# Altium schematic sheet Sheet4.SchDoc
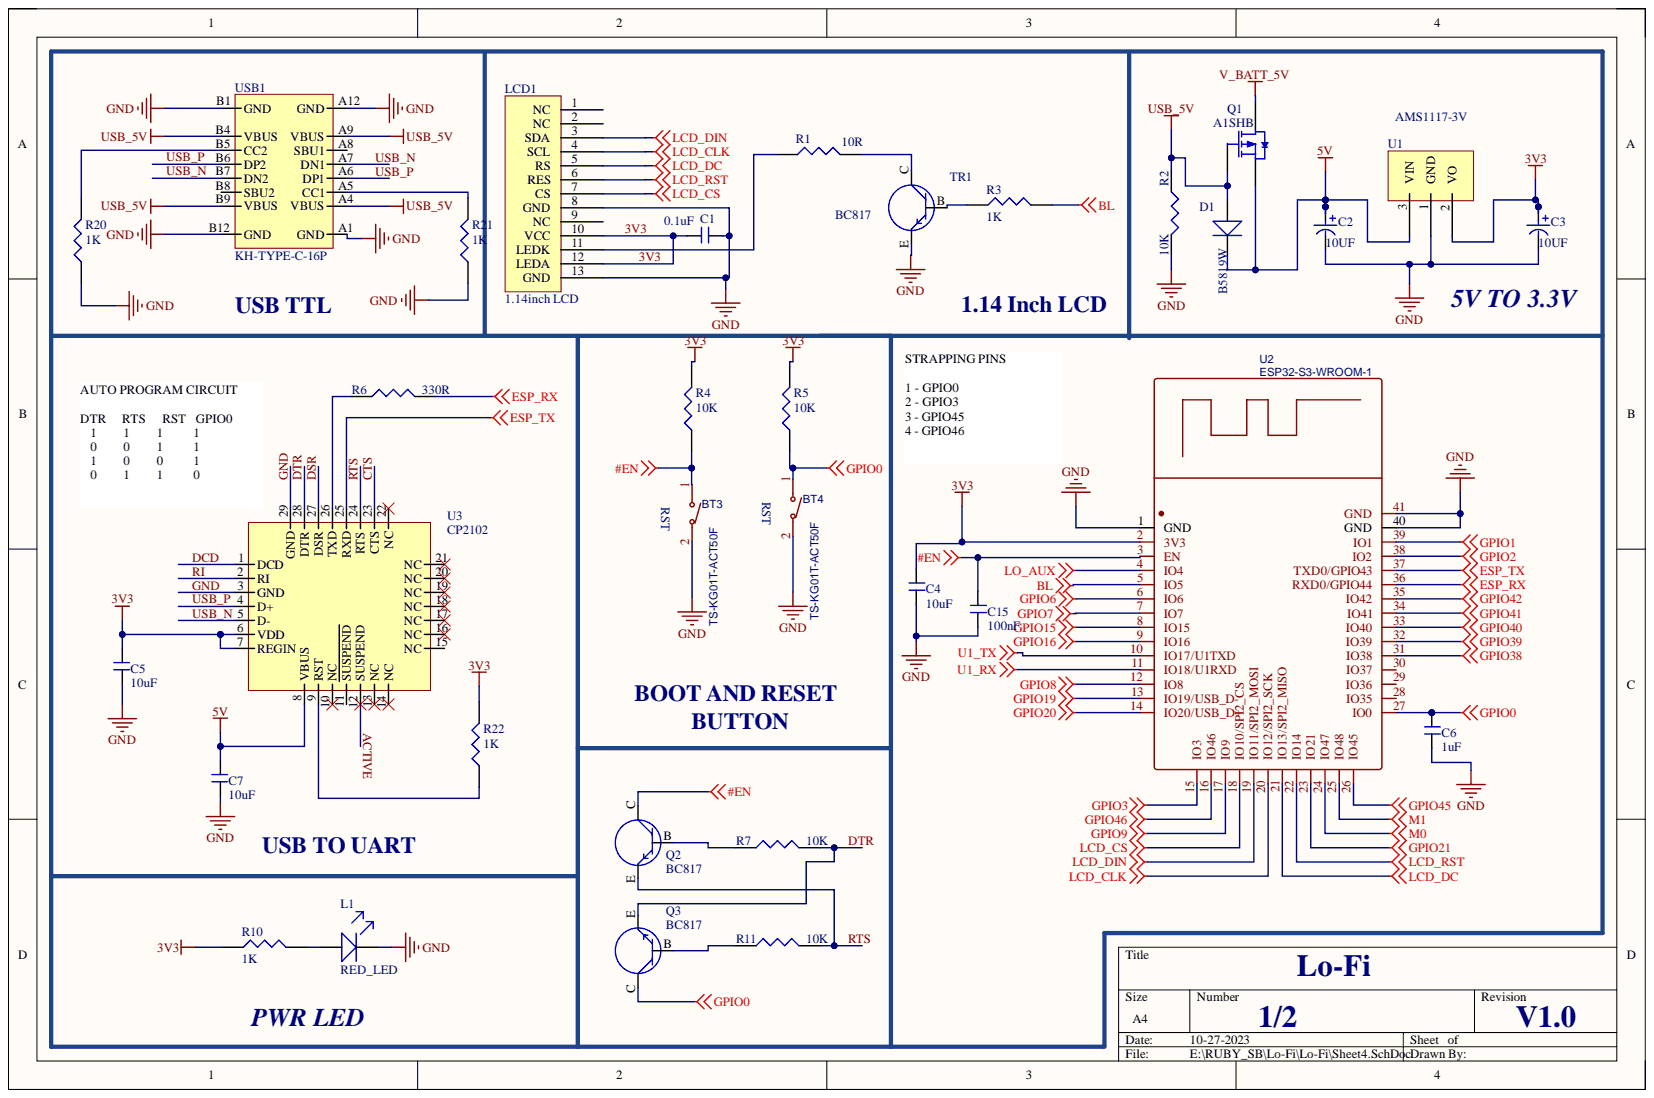
[diagram p0: Sheet4.SchDoc]

## Parts
BT3  TS-KG01T-ACT50F  [SW_SMD_L3.9_W2.9 P4.15]
BT4  TS-KG01T-ACT50F  [SW_SMD_L3.9_W2.9 P4.15]
BT?  TS-KG01T-ACT50F  [SW_SMD_L3.9_W2.9 P4.15]
C1  0.1uF  [C_0603]
C2  10UF  [Tantlum_A_3216]
C3  10UF  [Tantlum_A_3216]
C4  10uF  [C_0603]
C5  10uF  [C_0603]
C6  1uF  [C_0603]
C7  10uF  [C_0603]
C15  100nF  [C_0603]
D1  B5819W  [SOD-123]
L1  RED_LED  [LED_0603]
LCD1  1.14inch LCD  [1.14 inch]
Q1  A1SHB  [BSS84]
Q2  BC817  [SOT23]
Q3  BC817  [SOT23]
R1  10R  [R_0603]
R2  10K  [R_0603]
R3  1K  [R_0603]
R4  10K  [R_0603]
R5  10K  [R_0603]
R6  330R  [R_0603]
R7  10K  [R_0603]
R10  1K  [R_0603]
R11  10K  [R_0603]
R20  1K  [R_0603]
R21  1K  [R_0603]
R22  1K  [R_0603]
R?  10K  [R_0603]  (value not drawn)
TR1  BC817  [SOT23]
U1  AMS1117-3V  [AMS1117_3V3]
U2  ESP32-S3-WROOM-1  [ESP32-S3-WROOM1]
U3  CP2102  [CP2102]
USB1  KH-TYPE-C-16P  [USBC-31-M-12]

## Nets
5V: C2.1 C7.1 D1.K Q1.2 U1.3 U3.8(VBUS)
#EN: BT3.1 BT?.1 C15.2 Q2.C R4.1 R?.1 U2.3(EN)
BL: R3.1 U2.5(IO5)
ESP_RX: R6.2 U2.36(RXD0/GPIO44)
ESP_TX: U2.37(TXD0/GPIO43) U3.25(RXD)
GPIO0: BT4.1 C6.2 Q3.C R5.1 U2.27(IO0)
GPIO1: U2.39(IO1)
GPIO2: U2.38(IO2)
GPIO3: U2.15(IO3)
GPIO6: U2.6(IO6)
GPIO7: U2.7(IO7)
GPIO8: U2.12(IO8)
GPIO9: U2.17(IO9)
GPIO15: U2.8(IO15)
GPIO16: U2.9(IO16)
GPIO19: U2.13(IO19/USB_D-)
GPIO20: U2.14(IO20/USB_D+)
GPIO21: U2.23(IO21)
GPIO38: U2.31(IO38)
GPIO39: U2.32(IO39)
GPIO40: U2.33(IO40)
GPIO41: U2.34(IO41)
GPIO42: U2.35(IO42)
GPIO45: U2.26(IO45)
GPIO46: U2.16(IO46)
LCD_CLK: LCD1.4(SCL) U2.20(IO12/SPI2_SCK)
LCD_CS: LCD1.7(CS) U2.18(IO10/SPI2_CS)
LCD_DC: LCD1.5(RS) U2.21(IO13/SPI2_MISO)
LCD_DIN: LCD1.3(SDA) U2.19(IO11/SPI2_MOSI)
LCD_RST: LCD1.6(RES) U2.22(IO14)
LO_AUX: U2.4(IO4)
M0: U2.24(IO47)
M1: U2.25(IO48)
U1_RX: U2.11(IO18/U1RXD)
U1_TX: U2.10(IO17/U1TXD)
USB_5V: D1.A Q1.1 R2.1 USB1.A4(VBUS) USB1.A9(VBUS) USB1.B4(VBUS) USB1.B9(VBUS)
V_BATT_5V: Q1.3
3V3: C1.2 C3.1 C4.2 C5.1 LCD1.10(VCC) LCD1.12(LEDA) R4.2 R5.2 R10.1 R22.2 R?.2 U1.2 U2.2(3V3) U3.6(VDD) U3.7(REGIN)
ACTIVE: U3.12(SUSPEND)
CTS: U3.23(CTS)
DCD: U3.1(DCD)
DSR: U3.27(DSR)
DTR: Q3.E R7.2 U3.28(DTR)
GND: BT3.2 BT4.2 BT?.2 C1.1 C2.2 C3.2 C4.1 C5.2 C6.1 C7.2 C15.1 L1.K LCD1.8(GND) LCD1.13(GND) R2.2 R20.1 R21.1 TR1.E U1.1 U2.1(GND) U2.40(GND) U2.41(GND) U3.3(GND) U3.29(GND) USB1.A1(GND) USB1.A12(GND) USB1.B1(GND) USB1.B12(GND)
RI: U3.2(RI)
RTS: Q2.E R11.2 U3.24(RTS)
USB_N: U3.5(D-) USB1.A7(DN1) USB1.B7(DN2)
USB_P: U3.4(D+) USB1.A6(DP1) USB1.B6(DP2)
NetL1_A: L1.A R10.2
NetLCD1_11: LCD1.11(LEDK) R1.1
NetQ2_B: Q2.B R7.1
NetQ3_B: Q3.B R11.1
NetR1_2: R1.2 TR1.C
NetR3_2: R3.2 TR1.B
NetR6_1: R6.1 U3.26(TXD)
NetR20_2: R20.2 USB1.B5(CC2)
NetR21_2: R21.2 USB1.A5(CC1)
NetR22_1: R22.1 U3.9(RST)

Not connected: LCD1 1(NC) 2(NC) 9(NC); U2 28(IO35) 29(IO36) 30(IO37); U3 10(NC) 11(SUSPEND) 13(NC) 14(NC) 15(NC) 16(NC) 17(NC) 18(NC) 19(NC) 20(NC) 21(NC) 22(NC); USB1 A8(SBU1) B8(SBU2)

## Library data of the parts
BT3 BT4 BT? = TS-KG01T-ACT50F: BOM_Manufacturer: HANBO(汉博); Contributor: LCSC; BOM_JLCPCB Part Class: Extended Part; BOM_Manufacturer Part: TS-KG01T-ACT50F; BOM_Supplier: LCSC; BOM_Supplier Part: C2874211; Component Kind: Standard; spicePre: S; spiceSymbolName: TS-KG01T-ACT50F
C1 C4-C7 C15 = CAP_NONPOLAR: Component Kind: Standard; Library Name: dxp_pasl_lib.IntLib
C2 C3 = CAP_ELE: Component Kind: Standard; Library Name: MIX.SchLib
D1 = DIODE: Component Kind: Standard; Library Name: dxp_pasl_lib.IntLib
L1 = LED: Component Kind: Standard; Library Name: dxp_pasl_lib.IntLib
LCD1 = LCD 1.14INCH: 1.14inch LCD Display 65K RGB Colors, 240×135 Pixels, SPI Interface; Component Kind: Standard; Library Name: dxp_pasl_lib.IntLib
Q1 = BSS84: 50V 130mA 250mW 10Ω 10V,130mA 2V 1mA P Channel SOT-23(SOT-23-3) MOSFETs ROHS #C493579; PackageDocument: 1995/1996; CATEGORY: MOSFET; Component Kind: Standard; LatestRevisionDate: 17-Jul-2002; LatestRevisionNote: Re-released for DXP Platform.; PackageReference: E3; Published: 8-Jun-2000; Library Name: dxp_pasl_lib.IntLib; Publisher: Altium Limited
Q2 Q3 TR1 = TRANSISTER_NPN: Component Kind: Standard; Library Name: dxp_pasl_lib.IntLib
R1-R7 R10 R11 R20-R22 R? = RES: Component Kind: Standard; Library Name: dxp_pasl_lib.IntLib
U1 = AMS1117: Component Kind: Standard; Library Name: dxp_pasl_lib.IntLib
U2 = ESP32-S3-WROOM-1(N16): BOM_Manufacturer: ESPRESSIF(乐鑫); BOM_Manufacturer Part: ESP32-S3-WROOM-1-N16; Contributor: lcsc; BOM_JLCPCB Part Class: Extended Part; BOM_Supplier: LCSC; BOM_Supplier Part: C2913199; Component Kind: Standard; link: https://atta.szlcsc.com/upload/public/pdf/source/20220628/A2AC12B3C87735CAEB7EBE2DBE80936C.pdf; spicePre: U; spiceSymbolName: ESP32-S3-WROOM-1(N16)
U3 = CP2102_1: QFN, 28-Leads, Body 5.0x5.0mm (max), Pitch 0.50mm, IPC Medium Density; Component Kind: Standard; Library Name: dxp_pasl_lib.IntLib
USB1 = USB TYPE-C KH-TYPE-C-16P: 3A 1 Surface Mount 16 Female -40?~+85? Type-C SMD USB Connectors ROHS #  C709357; Component Kind: Standard; ComponentLink2Description: New link; ComponentLink2URL: https://lcsc.com/product-detail/USB-Connectors_Shenzhen-Kinghelm-Elec-KH-TYPE-C-16P_C709357.html; Supplier 1: LCSC; Supplier Part Number 1: C165948; Library Name: dxp_pasl_lib.IntLib
